# Altium schematic sheet Power.SchDoc(Power)
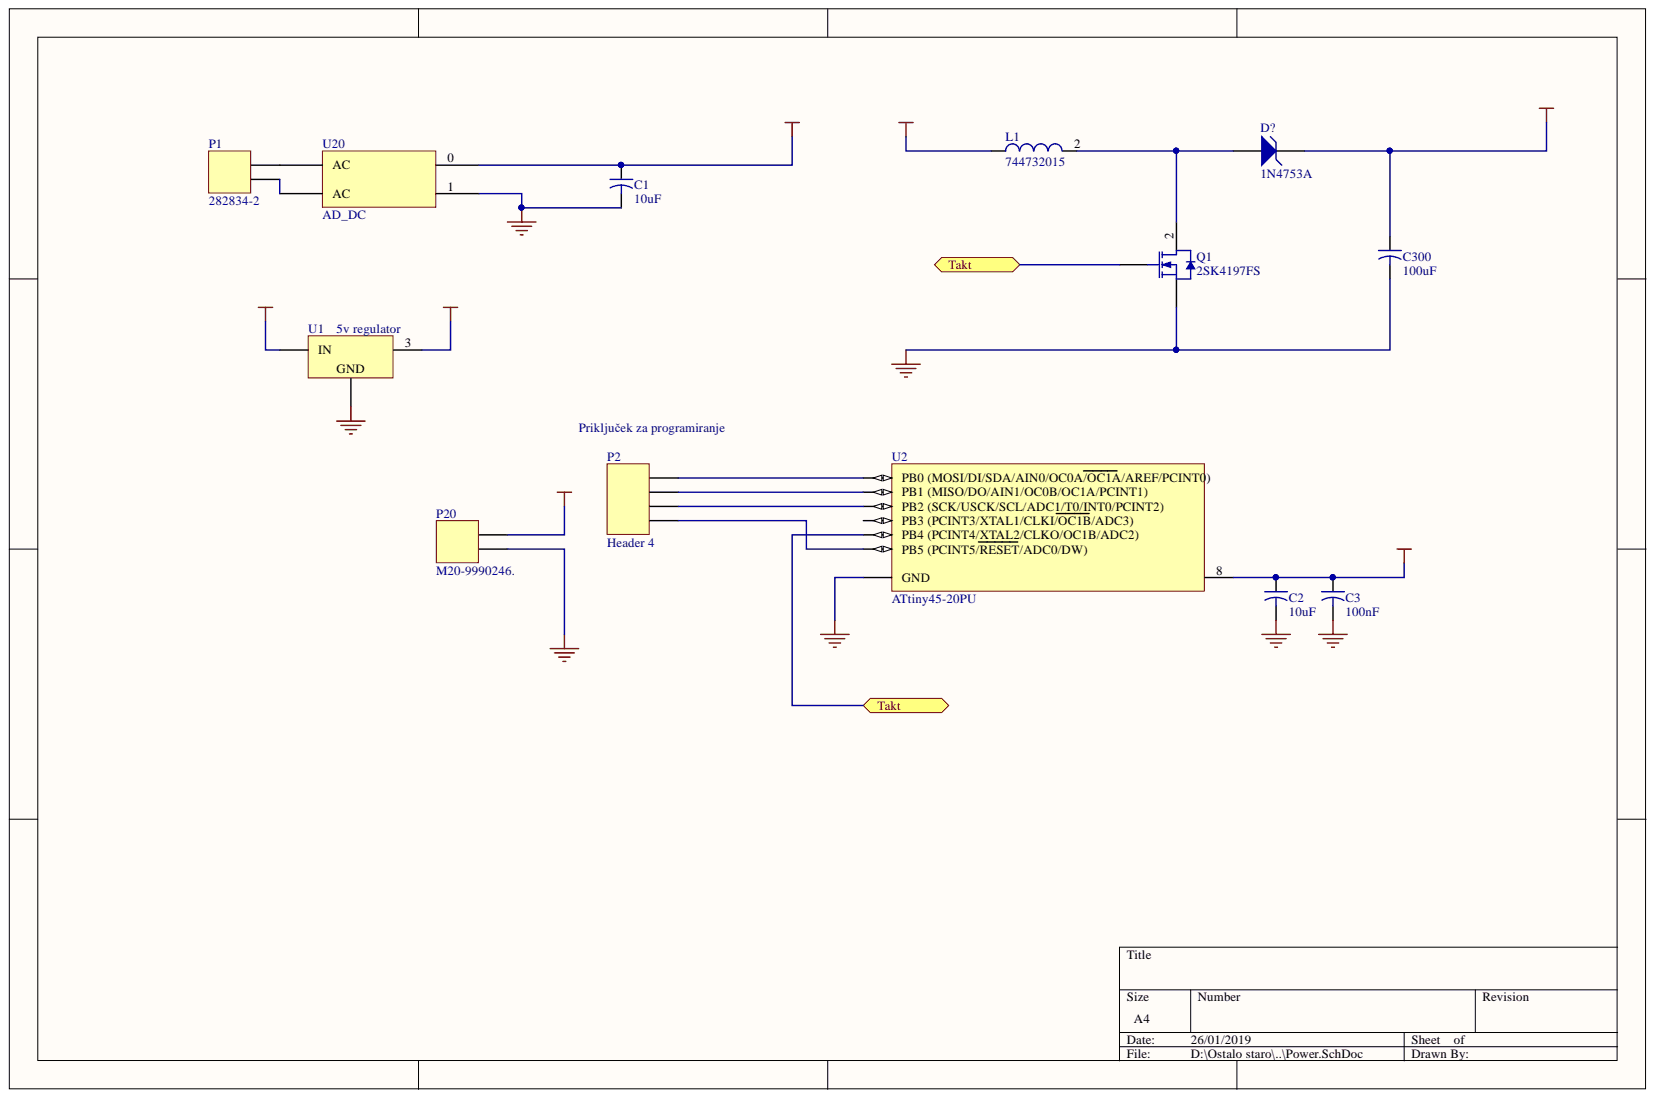
[diagram p0: Power.SchDoc]

## Parts
C1  value 10uF  [CAPPR2-5x7]  (hidden comment: Electrolytic capacitor)
C2  value 10uF  [CAPPR2-5x7]  (hidden comment: Electrolytic capacitor)
C3  value 100nF  [CAPPR2-5x7]  (hidden comment: Electrolytic capacitor)
C300  value 100uF  [CAPPR3.5-8x11.5]  (hidden comment: Electrolytic capacitor)
D?  1N4753A  [DO-41]
L1  744732015  [WE-TI-LM-STBG]
P1  282834-2  [TB 1x2 2.54]
P2  Header 4  [HDR1X4]
P20  M20-9990246.  [HDR 1X2 2.54 M]
Q1  2SK4197FS  [ONSC-TO-220F-3FS-3-221AM]
U1  5v regulator  [TO220]
U2  ATtiny45-20PU  [8P3]
U20  AD_DC  [PCBComponent_1]

## Ports
Takt

## Nets
5V: C2.1 C3.1 P20.1 U1.3 U2.8
12 V: C1.1 L1.1 U1.1 U20.0
180V: C300.1 D?.2
GND: C1.2 C2.2 C3.2 C300.2 P20.2 Q1.3 U1.2 U2.4(GND) U20.1
Takt: Q1.1 U2.3(PB4_(PCINT4/XTAL2/CLKO/OC1B/ADC2)) ; port Takt
NetD?_1: D?.1 L1.2 Q1.2
NetP1_1: P1.1 U20.2(AC)
NetP1_2: P1.2 U20.3(AC)
NetP2_1: P2.1 U2.1(PB5_(PCINT5/RESET/ADC0/DW))
NetP2_2: P2.2 U2.7(PB2_(SCK/USCK/SCL/ADC1/T0/INT0/PCINT2))
NetP2_3: P2.3 U2.6(PB1_(MISO/DO/AIN1/OC0B/OC1A/PCINT1))
NetP2_4: P2.4 U2.5(PB0_(MOSI/DI/SDA/AIN0/OC0A/OC1A/AREF/PCINT0))

Not connected: U2 2(PB3_(PCINT3/XTAL1/CLKI/OC1B/ADC3))

## Library data of the parts
C1-C3 = C El Rad 2 5x7: Capacitor; Signal Integrity: Model Name; Library Name: Capacitors.IntLib; Component Kind: Standard
C300 = C El Rad 3.5 8x11.5: Capacitor; Signal Integrity: Model Name; Library Name: Capacitors.IntLib; Component Kind: Standard
D? = 1N4753A: 1W Zener Diode; Simulation: 1N4753A; Signal Integrity: 1N4753A; Published: 8-Jun-2000; PackageReference: DO-41; Library Name: Vishay Lite-On Discrete Diode.IntLib; LatestRevisionNote: Re-released for DXP Platform.; LatestRevisionDate: 17-Jul-2002; DatasheetDocument: REV. H; ComponentLink2URL: http://www.vishay.com/docs/85816/85816.pdf; ComponentLink2Description: Datasheet; ComponentLink1URL: http://www.vishay.com/; ComponentLink1Description: Manufacturer Link; Component Kind: Standard; Code_JEDEC: DO-204-AL; Publisher: Altium Limited
L1 = 744732015: Radial Leaded Wire Wound Inductor WE-TI, L = 1.50 µH; PartNumber: 744732015; PackageReference: WE-TI-LM-STBG; PackageDescription: THT Inductor, 2-Leads, Body 9.5 x 9.5 mm; Library Name: WE-TI Radial Leaded Wire Wound Inductor.IntLib; DatasheetVersion: ver 4, Oct-2009; ComponentLink2URL: http://www.we-online.de/katalog/media/pdf/744732015.pdf; ComponentLink2Description: Datasheet; ComponentLink1URL: http://www.we-online.com; ComponentLink1Description: Manufacturer URL; Component Kind: Standard
P1 = 282834-2: TERMINAL BLOCK, WIRE TO BRD, 2POS, 16AWG; Manufacturer: TE CONNECTIVITY / BUCHANAN; Wire Size AWG Min: 30; Wire Size AWG Max: 16; Wire Connection Method: Screw; Rated Voltage: 150; Rated Current: 10; Pitch Spacing: 2.54; No. of Positions: 2; Connector Type: Terminal Block, Eurostyle; Connector Mounting: PCB Mount; Conductor Area CSA: 1.4; Block Orientation: Through Hole Right Angle; Supplier Part Number 1: 2112482; Supplier 1: Farnell; Stock 1: 6736; RoHS: YES; Pricing 1: 1=0,78, 10=0,713, 100=0,609, 500=0,547, 1000=0,493, 2500=0,451, 8000=0,442, 30000=0,433 (EUR); Manufacturer Part Number: 282834-2; Library Name: Connectors.IntLib; Contact Plating: Tin Plated Contacts<!--FANDB--><div class="features-and-benefits-container"><div class="features-and-benefits-description"><span class="features-benefits-value">The 282834-2 is a 1-row PCB mount Terminal Block Connector with a side wire entry and green polyamide 6.6 GF housings. This PCB screw terminal block connector have M1.6 nickel-plated brass screw terminals, tin-plated contacts and accept a wire size range of 0.05 to 1.40mm² (30 to 16; Contact Material: Brass; ComponentLink3URL: http://www.farnell.com/datasheets/583107.pdf; ComponentLink3Description: http://www.farnell.com/datasheets/583107.pdf; ComponentLink2URL: http://www.farnell.com/cad/1605505.pdf; ComponentLink2Description: http://www.farnell.com/cad/1605505.pdf; ComponentLink1URL: http://www.farnell.com/datasheets/504547.pdf; ComponentLink1Description: http://www.farnell.com/datasheets/504547.pdf; Component Kind: Standard
P2 = Header 4: Header, 4-Pin; Signal Integrity: Connector; Library Name: Miscellaneous Connectors.IntLib; LatestRevisionNote: Re-released for DXP Platform.; LatestRevisionDate: 17-Jul-2002; Component Kind: Standard; Publisher: Altium Limited
P20 = M20-9990246.: HEADER, STRAIGHT, 2.54MM 1ROW, 2WAY; Manufacturer: HARWIN; Supplier Part Number 1: 1022247; Supplier 1: Farnell; Stock 1: 41292; RoHS: YES; Pricing 1: 10=0,0618, 50=0,0562, 100=0,0485, 250=0,0468, 500=0,0353, 1000=0,032, 2500=0,0251, 5000=0,0245 (EUR); Manufacturer Part Number: M20-9990246.; Library Name: Connectors.IntLib; ComponentLink3URL: http://www.harwin.com/include/downloads/drawings/M20-999.PDF; ComponentLink3Description: http://www.harwin.com/include/downloads/drawings/M20-999.PDF; ComponentLink2URL: http://www.farnell.com/cad/315376.pdf; ComponentLink2Description: http://www.farnell.com/cad/315376.pdf; ComponentLink1URL: http://www.farnell.com/datasheets/1089605.pdf; ComponentLink1Description: http://www.farnell.com/datasheets/1089605.pdf; Component Kind: Standard
Q1 = 2SK4197FS: N-Channel Power MOSFET, 600 V, 3.5 A, 3.25 Ohm, 3-Pin TO-220, Pb-Free, Tube; Manufacturer: On Semiconductor; VGS Max (V): 30; VGS(th) Max (V): 5; V(BR)DSS Min (V): 600; Crss Typ (pF): 9.7; Coss Typ (pF): 50; Configuration: Single; Ciss Typ (pF): 260; Channel Polarity: N Channel; Supplier Part Number 2: 863-2SK4197FS; Supplier Part Number 1: 2SK4197FS-ND; Supplier 2: Mouser; Supplier 1: Digi-Key; RoHS: Pb-Free; PartNumber: 2SK4197FS; Packing: Tube; PackageVersion: Rev. O, 01/2012; Package Type: TO-220F-3FS; PackageReference: TO-220F-3FS-3-221AM; PackageDescription: 3-Pin Flange Mount, Vertical Straight Lead, Body 10.16 x 4.7 mm, Pitch 2.54 mm; Mounting Technology: Through Hole; Library Name: ON Semi MOSFETs.IntLib; ComponentLink3URL: http://www.onsemi.com/pub_link/Collateral/221AM.PDF; ComponentLink3Description: Package Specification; ComponentLink2URL: http://www.onsemi.com/pub_link/Collateral/ENA1368-D.PDF; ComponentLink2Description: Datasheet; ComponentLink1URL: http://www.onsemi.com/; ComponentLink1Description: Manufacturer URL; Component Kind: Standard
U1 = L78S24CV: 2A Positive Voltage Regulator, 24V, 3-Pin TO-220; PartNumber: L78S24CV; PackageVersion: ver3.0; PackageReference: TO220; PackageDescription: TO, Thru-Hole, Vertical, Heatsink Mounted; 3 In-Line Leads; Pitch 2.54 mm; Library Name: STMicroelectronics Power Management Linear Regulator.IntLib; DatasheetVersion: Mar-2010; ComponentLink3URL: http://www.st.com/internet/com/TECHNICAL_RESOURCES/TECHNICAL_LITERATURE/PACKAGE_INFORMATION/CD00004649.pdf; ComponentLink3Description: Package Specification; ComponentLink2URL: http://www.st.com/internet/com/TECHNICAL_RESOURCES/TECHNICAL_LITERATURE/DATASHEET/CD00000449.pdf; ComponentLink2Description: Datasheet; ComponentLink1URL: http://www.st.com; ComponentLink1Description: Manufacturer URL; Component Kind: Standard
U2 = ATtiny45-20PU: 8-bit AVR Microcontroller, 20MHz, 2.7-5.5V, 4K Flash, 256 Bytes EEPROM, 256 Bytes SRAM, Industrial Grade (-40°C to 85°C), 8-Lead PDIP, Pb-Free; PackageVersion: revB, Jan-2002; PackageReference: 8P3; PackageDescription: 8-Lead, 0.300" Wide Body, Plastic Dual In-Line Package (PDIP); Library Name: Atmel Microcontroller tinyAVR.IntLib; DatasheetVersion: revN, Apr-2011; ComponentLink3URL: http://www.atmel.com/dyn/resources/prod_documents/2586S.pdf; ComponentLink3Description: ATtiny25/45/85 Summary; ComponentLink2URL: http://www.atmel.com/dyn/resources/prod_documents/doc2586.pdf; ComponentLink2Description: ATtiny25/45/85 Datasheet; ComponentLink1URL: http://www.atmel.com/; ComponentLink1Description: Manufacturer URL; Component Kind: Standard; Code_JEDEC: MS-001-BA
U20 = AD_DC: Library Name: ad-dc.SchLib; Component Kind: Standard
